# Altium schematic sheet captivate_iso.SchDoc(captivate_iso)
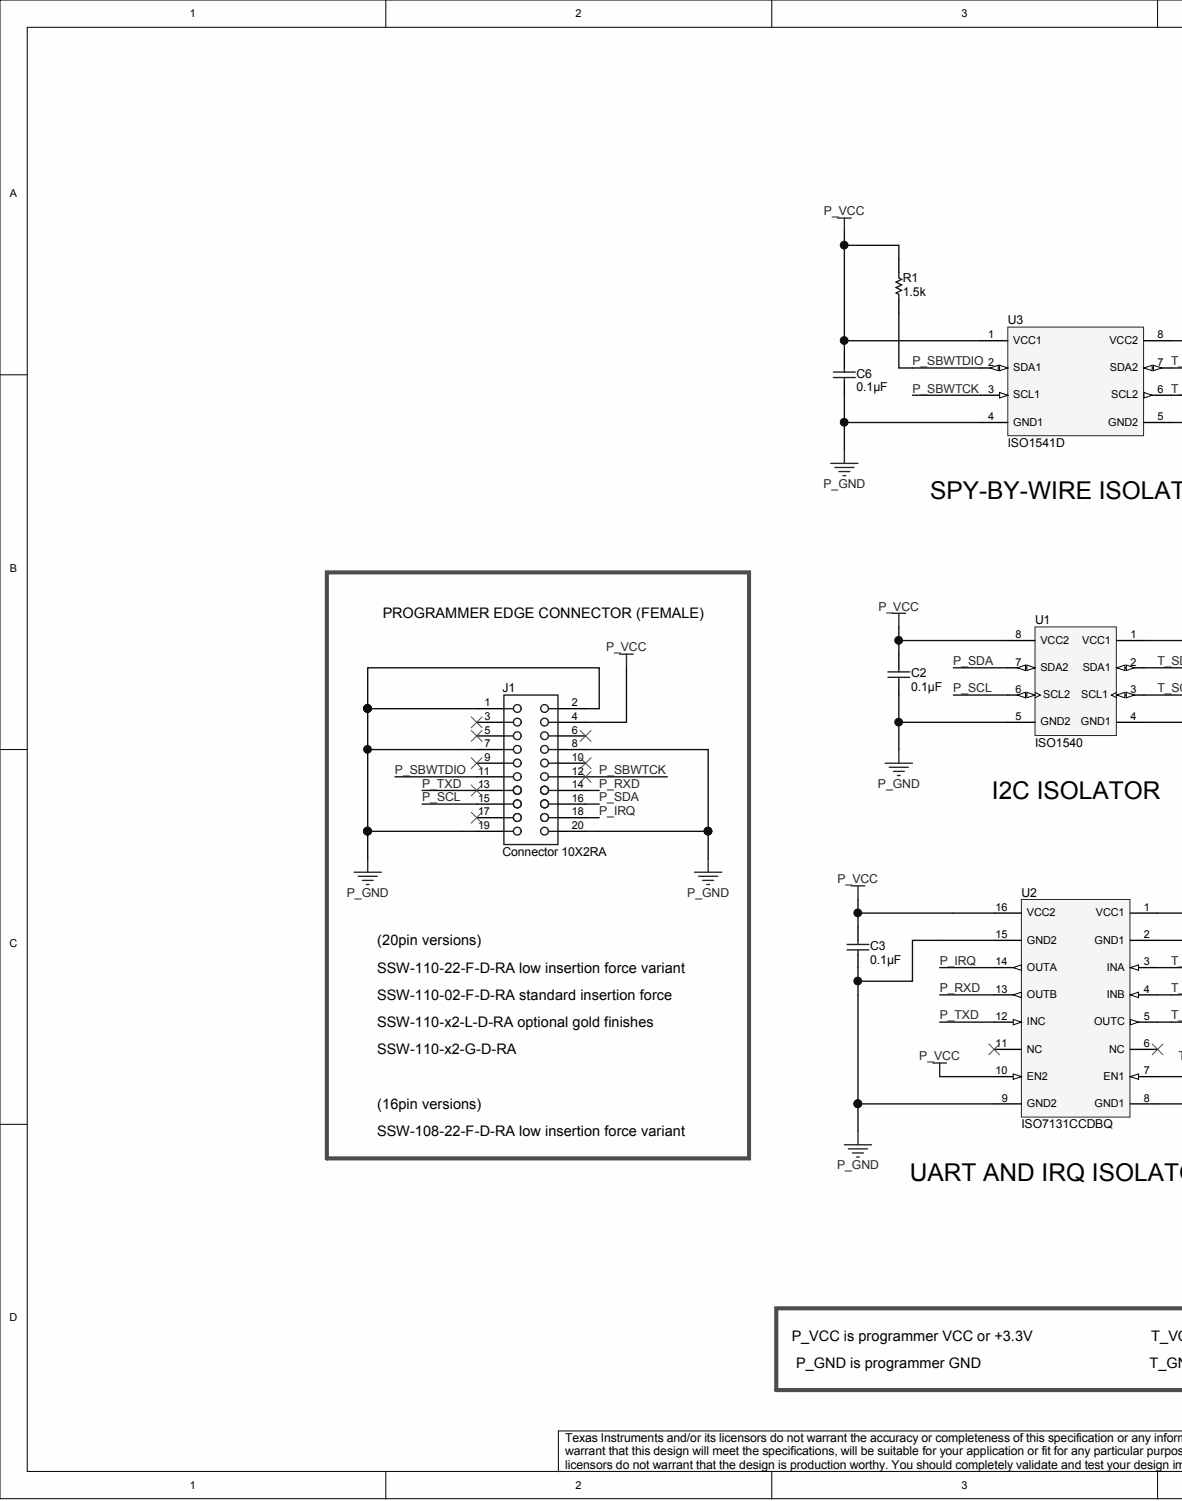
[diagram p0: captivate_iso.SchDoc, left]
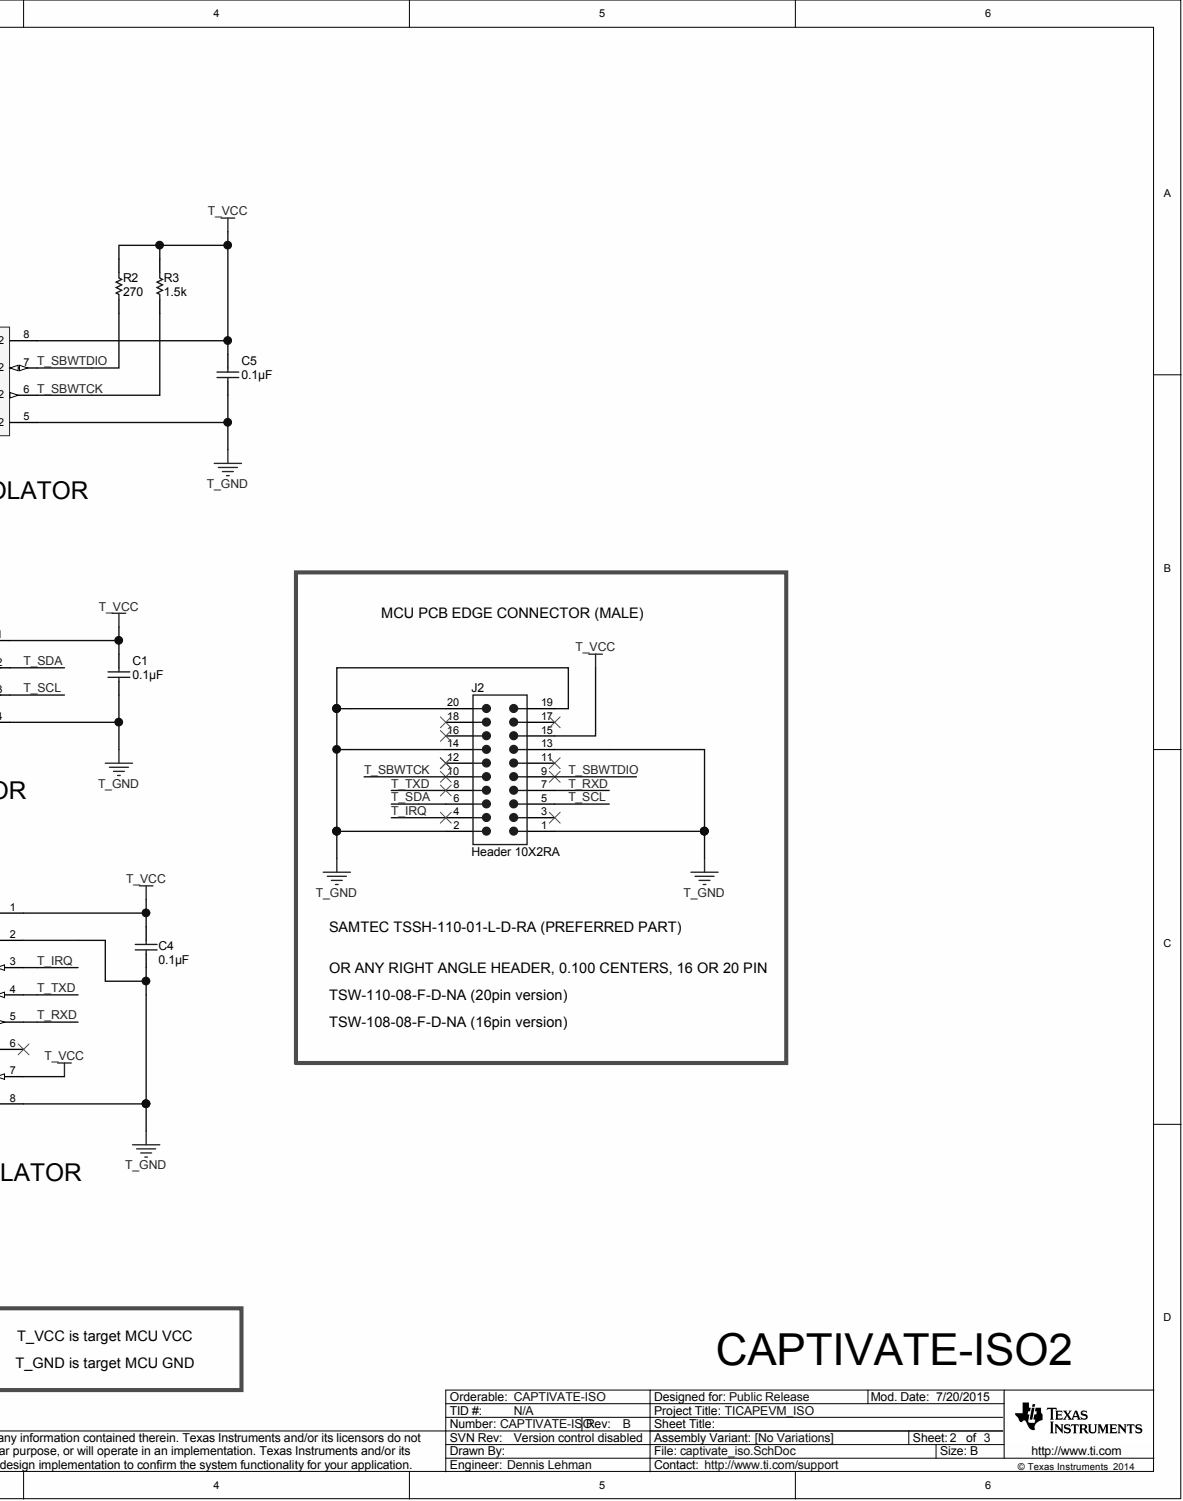
[diagram p1: captivate_iso.SchDoc, right]

## Parts
C1  ValueDisplayed: 0.1µF  [0402]  (hidden comment: C1005X5R1A104K)
C2  ValueDisplayed: 0.1µF  [0402]  (hidden comment: C1005X5R1A104K)
C3  ValueDisplayed: 0.1µF  [0402]  (hidden comment: C1005X5R1A104K)
C4  ValueDisplayed: 0.1µF  [0402]  (hidden comment: C1005X5R1A104K)
C5  ValueDisplayed: 0.1µF  [0402]  (hidden comment: C1005X5R1A104K)
C6  ValueDisplayed: 0.1µF  [0402]  (hidden comment: C1005X5R1A104K)
J1  Connector 10X2RA  [CONN2X10RA]
J2  Header 10X2RA  [HDR2X10RA]
R1  value 1.5k  [0402]  (hidden comment: CRCW04021K50JNED)
R2  value 270  [0402]  (hidden comment: CRCW0402270RJNED)
R3  value 1.5k  [0402]  (hidden comment: CRCW04021K50JNED)
U1  ISO1540  [D0008A_L]
U2  ISO7131CCDBQ  [DBQ0016A_N]
U3  ISO1541D  [D0008A_N]

## Nets
P_GND: C2.1 C3.1 C6.1 J1.1 J1.2 J1.7 J1.8 J1.19 J1.20 U1.5(GND2) U2.9(GND2) U2.15(GND2) U3.4(GND1)
P_VCC: C2.2 C3.2 C6.2 J1.4 R1.2 U1.8(VCC2) U2.10(EN2) U2.16(VCC2) U3.1(VCC1)
T_GND: C1.2 C4.1 C5.2 J2.1 J2.2 J2.13 J2.14 J2.19 J2.20 U1.4(GND1) U2.2(GND1) U2.8(GND1) U3.5(GND2)
T_VCC: C1.1 C4.2 C5.1 J2.15 R2.2 R3.2 U1.1(VCC1) U2.1(VCC1) U2.7(EN1) U3.8(VCC2)
P_IRQ: J1.18 U2.14(OUTA)
P_RXD: J1.14 U2.13(OUTB)
P_SBWTCK: J1.12 U3.3(SCL1)
P_SBWTDIO: J1.11 R1.1 U3.2(SDA1)
P_SCL: J1.15 U1.6(SCL2)
P_SDA: J1.16 U1.7(SDA2)
P_TXD: J1.13 U2.12(INC)
T_IRQ: J2.4 U2.3(INA)
T_RXD: J2.7 U2.5(OUTC)
T_SBWTCK: J2.10 R3.1 U3.6(SCL2)
T_SBWTDIO: J2.9 R2.1 U3.7(SDA2)
T_SCL: J2.5 U1.3(SCL1)
T_SDA: J2.6 U1.2(SDA1)
T_TXD: J2.8 U2.4(INB)

Not connected: J1 3 5 6 9 10 17; J2 3 11 12 16 17 18; U2 6(NC) 11(NC)

## Library data of the parts
C1-C6 = C1005X5R1A104K: CAP, CERM, 0.1 µF, 10 V, +/- 10%, X5R, 0402; Manufacturer: TDK; Cap_F: 0.0000001; CompType: Capacitor; ESR_ohm: 0.0186; Polarized: N; TaMax_C: 85; TaMin_C: -55; Tolerance_%: 10; U/M: EA; Value: 0.1uF; Value1: 0.0186 ohm; Value2: 10V; Cap_Case: 0402; Component Kind: Standard; ComponentLink1Description: Datasheet link; ComponentLink1URL: http://www.tdk.co.jp/tefe02/e412_c.pdf; LatestRevisionDate: 2014-12-10; Library Name: Capacitor_Ceramic.IntLib; MountType: SMT; PackageReference: 0402; PartNumber: C1005X5R1A104K; Published: 2008-06-19; RoHS: Y; Signal Integrity: Cap; Simulation: Cap; Supplier 1: Digi-Key; Supplier 2: Farnell; Supplier Part Number 1: 445-1265-1-ND; Supplier Part Number 2: 1844111; SymbolVersion: 2008-07-09; Technology: Ceramic; TempCo: X5R; VDC_V: 10; Publisher: Texas Instruments
J1 = Connector 10X2RA: Connector, Female, 10-Pin, 2 row, Right Angle; Alternate PartNumber: SSW-110-02-F-D-RA; Manufacturer: Samtec; PartNumber: SSW-110-22-F-D-RA; Component Kind: Standard; LatestRevisionDate: 17-Jul-2002; LatestRevisionNote: Re-released for DXP Platform.; Signal Integrity: Connector; Library Name: Captivate Library.IntLib; Publisher: Altium Limited
J2 = Header 10X2RA: Header, Male, 10-Pin, 2 row, Shround, Right Angle; Manufacturer: Samtec; PartNumber: TSSH-110-01-L-D-RA; Component Kind: Standard; LatestRevisionDate: 17-Jul-2002; LatestRevisionNote: Re-released for DXP Platform.; Signal Integrity: Connector; Supplier: Samtec; Library Name: Captivate Library.IntLib; Publisher: Altium Limited
R1 R3 = CRCW04021K50JNED: RES, 1.5 k, 5%, 0.063 W, 0402; Manufacturer: Vishay-Dale; CompType: Resistor; EIA_Code: E24; Power_W: 0.063; Res_ohm: 1500; TempCo: 200 ppm/C; Tolerance_%: 5; U/M: EA; Value2: 0.063W; Value_Code: 1k50; VDC_V: 50; Component Kind: Standard; ComponentLink1Description: Datasheet link; ComponentLink1URL: http://www.vishay.com/docs/20035/dcrcwe3.pdf; LatestRevisionDate: 2015-05-08; Library Name: Resistor_0402.IntLib; MountType: SMT; PackageReference: 0402; PartNumber: CRCW04021K50JNED; Published: 2008-06-26; RoHS: Y; Signal Integrity: Resistor; Simulation: Resistor; Supplier 1: Digi-Key; Supplier 2: Mouser; Supplier 3: Farnell; Supplier Part Number 1: 541-1.5KJCT-ND; Supplier Part Number 2: 71-CRCW0402J-1.5K-E3; Supplier Part Number 3: 1558782; SymbolVersion: 2009-05-29; Technology: Thick Film; Value1: 5%; ValueDisplayed: 1.5k; Publisher: Texas Instruments
R2 = CRCW0402270RJNED: RES, 270, 5%, 0.063 W, 0402; Manufacturer: Vishay-Dale; CompType: Resistor; EIA_Code: E24; Power_W: 0.063; TempCo: 200 ppm/C; Tolerance_%: 5; U/M: EA; Value2: 0.063W; Value_Code: 270R; VDC_V: 50; Component Kind: Standard; ComponentLink1Description: Datasheet link; ComponentLink1URL: http://www.vishay.com/docs/20035/dcrcwe3.pdf; LatestRevisionDate: 2015-05-08; Library Name: Resistor_0402.IntLib; MountType: SMT; PackageReference: 0402; PartNumber: CRCW0402270RJNED; Published: 2008-06-26; Res_ohm: 270; RoHS: Y; Signal Integrity: Resistor; Simulation: Resistor; Supplier 1: Digi-Key; Supplier 2: Mouser; Supplier 3: Farnell; Supplier Part Number 1: 541-270JCT-ND; Supplier Part Number 2: 71-CRCW0402J-270-E3; Supplier Part Number 3: 1514691; SymbolVersion: 2009-05-29; Technology: Thick Film; Value1: 5%; ValueDisplayed: 270; Publisher: Texas Instruments
U1 = ISO1540: I2C Bidirectional Isolator, 2 Channel Width, 3.0 to 12.0 V, -40 to 85 degC, 8-pin SOIC (D), Green (RoHS & no Sb/Br); Alternate Manufacturer: None; Alternate PartNumber: Equivalent; Manufacturer: Texas Instruments; 5V Tolerant I/O: Yes; Channel Width: 2; Master Side I2C Bus Capacitance Supported(pF): 400; VCC(Max)(V): 12; VCC(Min)(V): 3; Code_JEDEC: MS-012AA; Component Kind: Standard; ComponentLink1Description: Datasheet; ComponentLink1URL: http://www.ti.com/general/docs/lit/getliterature.tsp?genericPartNumber=P82B715&fileType=pdf; ComponentLink2Description: URL Link1; ComponentLink2URL: SamplesURL:http://www.ti.com/product/iso1540#samples; DatasheetVersion: SCPS145A; Eco-Plan: Green (RoHS & no Sb/Br); Max Frequency(kHz): 400; MountType: SMT; Open Drain I/O Type: Yes; PackageDescription: 8-Pin Plastic Small Outline Integrated Circuit, 4.9 x 3.9 mm, Pitch 1.27 mm Pitch; PackageReference: D0008A; PackageVersion: revM, Jun-2011; PartNumber: ISO1540; Slave Side I2C Bus Capacitance Supported(pF): 400; SubFamily: I2C Bidirectional Isolator; Supplier 1: Digi-Key; Supplier Part Number 1: 296-34896-5-ND; Vcc range(V): 3.0 to 12.0; Library Name: Captivate Library.IntLib
U2 = ISO7131CCDBQ: 4242-VPK Small-Footprint and Low-Power Triple Channels Digital Isolators, DBQ0016A; Alternate Manufacturer: Texas Instruments; CompType: IC; SymbolCreator: a0799628; U/M: EA; Component Kind: Standard; ComponentLink1Description: Datasheet Link; ComponentLink1URL: http://www.ti.com/lit/ds/symlink/iso7141cc.pdf; LatestRevisionDate: 2014-12-10; Library Name: TI_Pre_Release.IntLib; Manufacturer: Texas Instruments; MountType: SMT; PackageReference: DBQ0016A; PartNumber: ISO7131CCDBQ; RoHS: Y; Supplier 1: Digikey; Supplier Part Number 1: 296-40134-2-ND; SymbolVersion: 2013-09-25; Publisher: Texas Instruments
U3 = ISO1541D: Low-Power Bidirectional I2C Isolators, D0008A; Alternate Manufacturer: Texas Instruments; CompType: IC; SymbolCreator: a0411947; U/M: EA; Component Kind: Standard; ComponentLink1Description: Datasheet Link; ComponentLink1URL: http://www.ti.com/lit/ds/symlink/iso1541.pdf; LatestRevisionDate: 2015-05-12; Library Name: TI_Pre_Release.IntLib; Manufacturer: Texas Instruments; MountType: SMT; PackageReference: D0008A; PartNumber: ISO1541D; RoHS: Y; Supplier 1: Digikey; Supplier Part Number 1: 296-34872-2-ND; SymbolVersion: 2013-04-30; Publisher: Texas Instruments
